# Altium schematic sheet Power.SchDoc
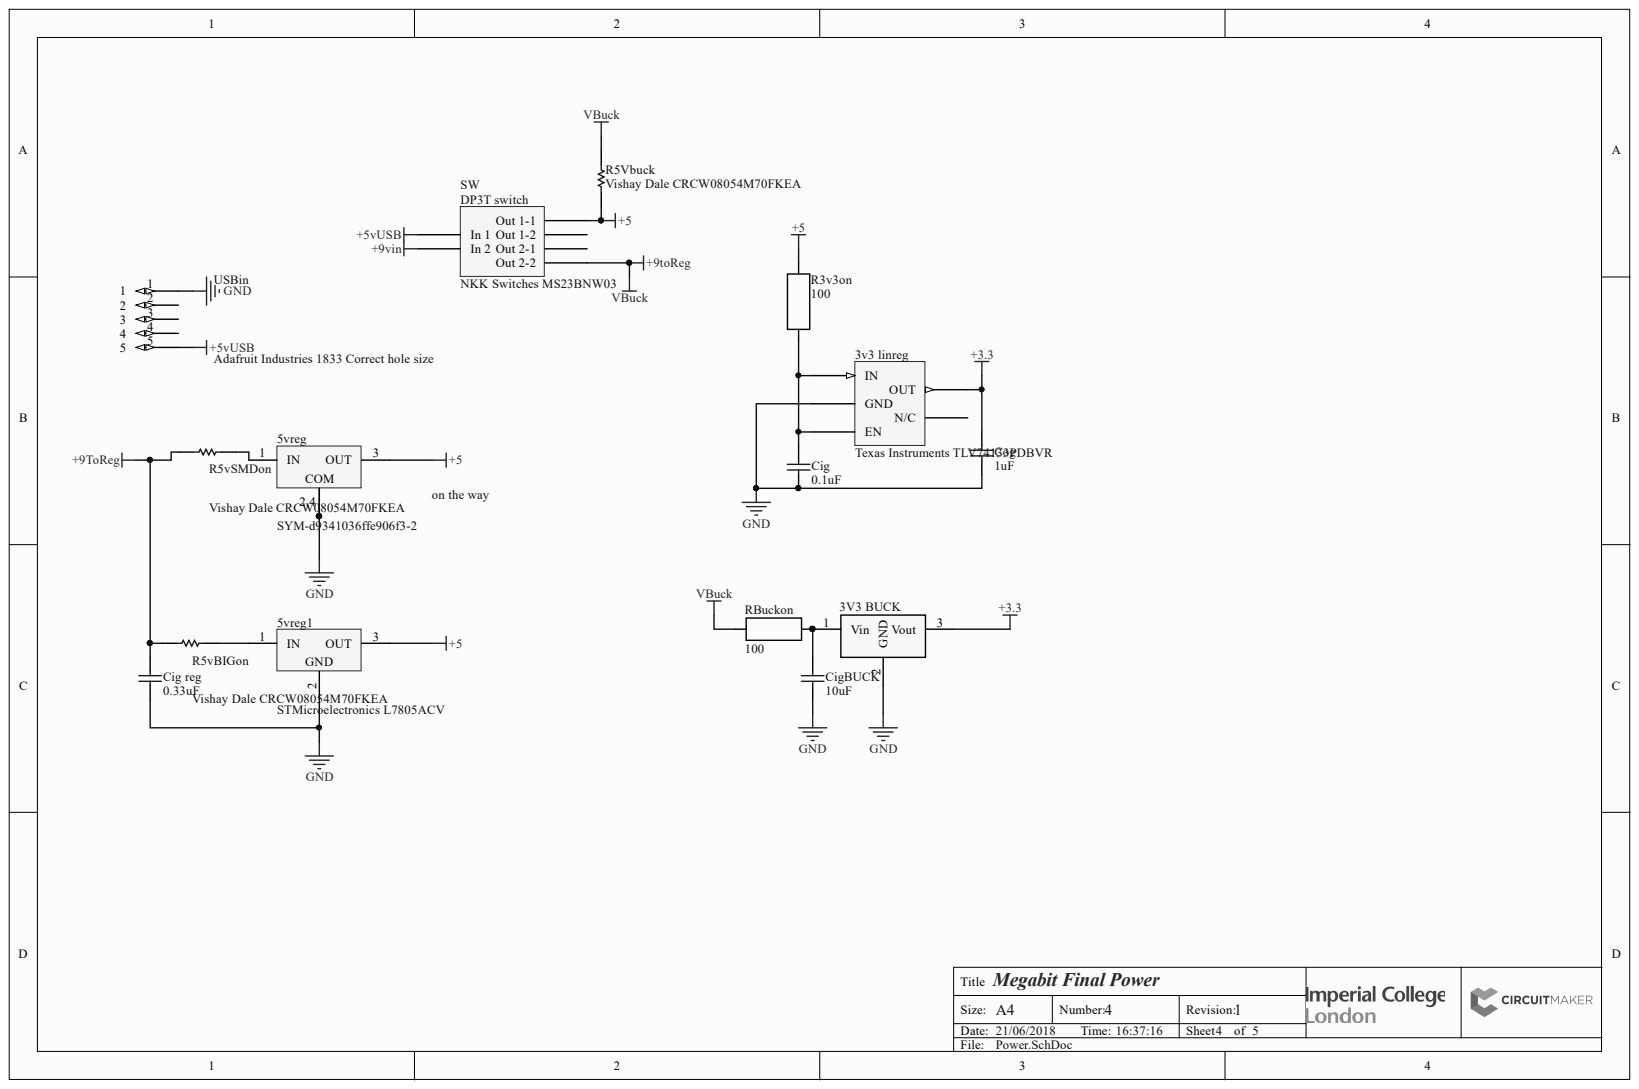
[diagram p0: Power.SchDoc]

## Parts
3V3_BUCK  Tracopower TSR 1-2433  [PCB-vczw4772xz5kvcrv4x78-1]  (value not drawn)
3v3_linreg  Texas Instruments TLV74133PDBVR  [PCB-e30blpvsozc7nnftiis2-1]
5vreg  SYM-d9341036ffe906f3-2  [SOT230P700X180-4L]
5vreg1  STMicroelectronics L7805ACV  Manufacturer Part Number: L7805ACV  [PCB-rumztmkd2xxusznmwboq-1]
Cig  0.1uF  [Vishay K104K15X7RF5TL2]
Cig_reg  0.33uF  [Vishay K104K15X7RF5TL2]
CigBUCK  10uF  [Vishay K104K15X7RF5TL2]
Cog  1uF  [Vishay K104K15X7RF5TL2]
R3v3on  100  [PCB-82a1bnj7v2r60fjh59dm-1]
R5vBIGon  Vishay Dale CRCW08054M70FKEA  [RESC2013X50X30LL20T20]
R5Vbuck  Vishay Dale CRCW08054M70FKEA  Manufacturer: Vishay  [RESC2013X50X30LL20T20]
R5vSMDon  Vishay Dale CRCW08054M70FKEA  [RESC2013X50X30LL20T20]
RBuckon  100  [PCB-82a1bnj7v2r60fjh59dm-1]
SW  NKK Switches MS23BNW03  [PCB-eozfiwzr1uk89188ni00-1]
USBin  Adafruit Industries 1833 Correct hole size  [PCB-lrx1ysvqy1j2hi3n37c6-1]

## Nets
+3.3: 3V3_BUCK.3 3v3_linreg.4(OUT) Cog.2
+5: 5vreg.3(OUT) 5vreg1.3 R3v3on.1 R5Vbuck.2 SW.1(Out_1-1)
+5VUSB: SW.2(In_1) USBin.5
+9TOREG: Cig_reg.2 R5vBIGon.2 R5Vbuck.1 R5vSMDon.2 RBuckon.1 SW.6(Out_2-2)
+9VIN: SW.5(In_2)
GND: 3V3_BUCK.2 3v3_linreg.2(GND) 5vreg.2 5vreg.4 5vreg1.2 Cig.1 Cig_reg.1 CigBUCK.1 Cog.1 USBin.1
Net3V3 BUCK_1: 3V3_BUCK.1 CigBUCK.2 RBuckon.2
Net3v3 linreg_1: 3v3_linreg.1(IN) 3v3_linreg.3(EN) Cig.2 R3v3on.2
Net5vreg1_1: 5vreg1.1 R5vBIGon.1
Net5vreg_1: 5vreg.1(IN) R5vSMDon.1

Not connected: 3v3_linreg 5(N/C); SW 3(Out_1-2) 4(Out_2-1); USBin 2 3 4

## Library data of the parts
3V3_BUCK = CMP-395cf0c79334e69b-1: 1 A Watt, 4.75-36VDCVin, Quad Output, 3.3 V@1 A Switching Regulators DC-DC Converter; Input Voltage (DC): 4.75 V to 36.0 V; Number of Outputs: 1; Output Current: 1.00 A; Output Voltage: 3.30 V; Component Kind: Standard; Library Name: CommunityVault; Manufacturer Part Number: TSR 1-2433; Mounting Style: PCB; OctopartID: 395cf0c79334e69b; Octopart URL: http://octopart.com/tsr+1-2433-tracopower-9539342; REACH SVHC Compliance: No SVHC; RoHS: Compliant; Size-Height: 10.2 mm; Size-Width: 7.60 mm
3v3_linreg = CMP-ad66616159c672a1-6: 150mA Low-Dropout (LDO) Regulator With Foldback Current Limit for Portable Devices 5-SOT-23 -40 to 125; Component Kind: Standard; Library Name: FavoritesLibrary
5vreg = CMP-d9341036ffe906f3-4: 3/4 Pin 500mA Fixed 5V Positive Voltage Regulator 4-SOT-223 0 to 125; Input Voltage (DC): 25.0 V (max); Lead-Free Status: Lead Free; Output Capacitor Type: Capacitor Free; Supply Voltage (DC): 25.0 V; Case/Package: SOT-223; Component Kind: Standard; Library Name: CommunityVault
5vreg1 = CMP-1170713-16: L7805 Series 1.5 A 5 V Three Terminal Voltage Regulator - TO-220; Dropout Voltage: 2.00 V; Input Voltage (DC): 20.0 V (max); Lead-Free Status: Lead Free; Number of Outputs: 1; Output Current: 1.00 A, 1.50 A; Output Voltage: 5.00 V (max); Case/Package: TO-220; Component Kind: Standard; Library Name: FavoritesLibrary; Lifecycle Status: Active; Mounting Style: Through Hole; Number of Pins: 3; OctopartID: b0837beb020f6f44; Octopart URL: https://octopart.com/l7805acv-stmicroelectronics-40015850; Operating Temperature: 0.00 °C to 150 °C; Packaging: Tube; REACH SVHC Compliance: No SVHC; RoHS: Compliant
Cig Cig_reg CigBUCK Cog = CMP-124240-16: K Series 100nF 50 V ±10 % Tolerance X7R Radial Multilayer Ceramic Capacitor; Manufacturer Part Number: K104K15X7RF5TL2; Capacitance Tolerance: ±10%; Lead-Free Status: Lead Free; Lead Length: 30mm; Voltage Rating (DC): 50 V; Capacitance: 100 nF; Component Kind: Standard; Dielectric Characteristic: X7R; Dielectric Material: Ceramic; Library Name: CommunityVault; Mounting Style: Through Hole; Number of Pins: 2; OctopartID: e7292e20c87538ee; Octopart URL: http://octopart.com/k104k15x7rf5tl2-vishay+bccomponents-199226; Packaging: Cut Tape (CT), Tape & Reel (TR); RoHS: Compliant; Size-Height: 5.6mm; Size-Lead Diameter: 0.5mm; Size-Thickness: 2.6mm; Size-Width: 4.0mm
R3v3on RBuckon = CMP-1867413-5: Resistor 0805 125mW; Resistance: Any resistance; Temperature Coefficient: -100 ppm/°C to 100 ppm/°C; Voltage Rating (DC): 150 V; Case/Package: 0805; Component Kind: Standard; Library Name: CommunityVault; Mounting Style: Surface Mount; OctopartID: dd051650670a02c2; Operating Temperature: 155 °C (max); Power Rating: 125 mW; Size-Height: 450 µm; Size-Length: 2.00 mm; Size-Width: 1.25 mm
R5vBIGon R5Vbuck R5vSMDon = CMP-1857827-1: Thick Film Resistors - SMD 1/8watt 4.7Mohms 1%; Brand: Vishay / Dale; Case Height: 0.45 mm; Case Length: 2 mm; Case Width: 1.25 mm; Power Rating: 125 mW (1/8 W); Temperature Coefficient: 100 PPM / K; Unit Weight: 0.000194 oz; Voltage Rating: 150 V; Case Code - in: 0805; Case Code - mm: 2012; CiivaID: 1857827; Ciiva URL: https://ciiva.com/part/crcw08054m70fkea-1857827; Component Kind: Standard; Dimensions: 1.25 mm W x 2 mm L x 0.45 mm H; Factory Pack Quantity: 5000; Library Name: CommunityVault; Manufacturer Part Number: CRCW08054M70FKEA; Operating Temperature Range: - 55 C to + 155 C; Package / Case: 0805 (2012 metric); Packaging: Reel; Product: Thick Film Resistors SMD; Product Category: Thick Film Resistors - SMD; Resistance: 4.7 MOhms; RoHS: Details; Series: CRCW; Termination Style: SMD/SMT; Tolerance: 1 %
  R5vBIGon R5vSMDon: Manufacturer: Vishay
SW = CMP-e82c21266ecb37eb-4: dp3t on off on switch; Component Kind: Standard; Library Name: FavoritesLibrary
USBin = CMP-bfb17591a6f36076-2: Standoff; Threaded Female 1/4 Hex; 6-32 Thread; Length .875; Brass/Zinc; Manufacturer Part Number: 1833; Lead-Free Status: Lead Free; Component Kind: Standard; Gender: Female; Library Name: FavoritesLibrary; Material: Brass; OctopartID: bfb17591a6f36076; Octopart URL: http://octopart.com/1833-adafruit+industries-53099556; RoHS: Compliant; Size-Diameter: 6.35 mm; Size-Length: 22.2 mm; Size-Width: 6.35 mm
